# Altium schematic sheet Sensor.SchDoc
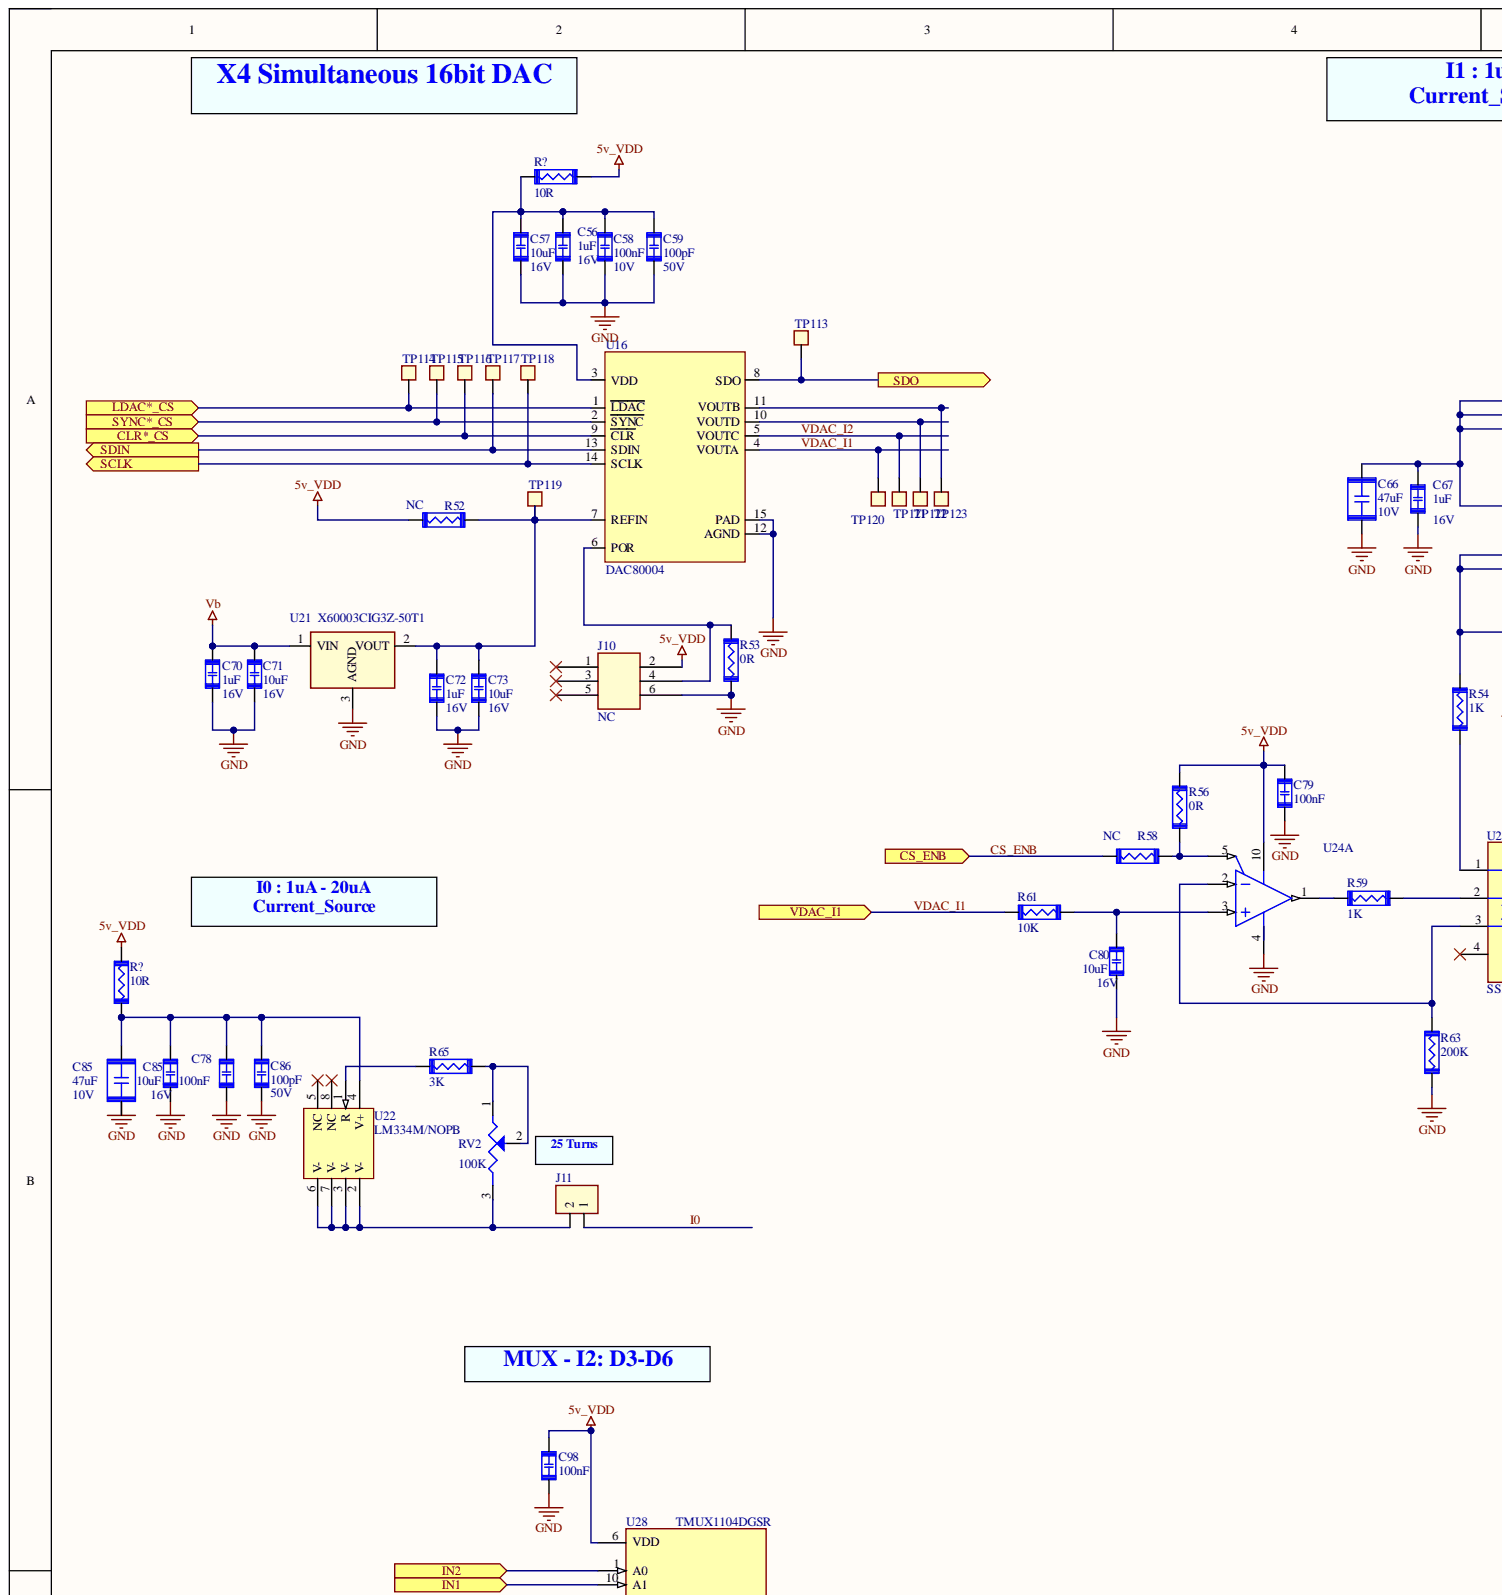
[diagram p0: Sensor.SchDoc, top left]
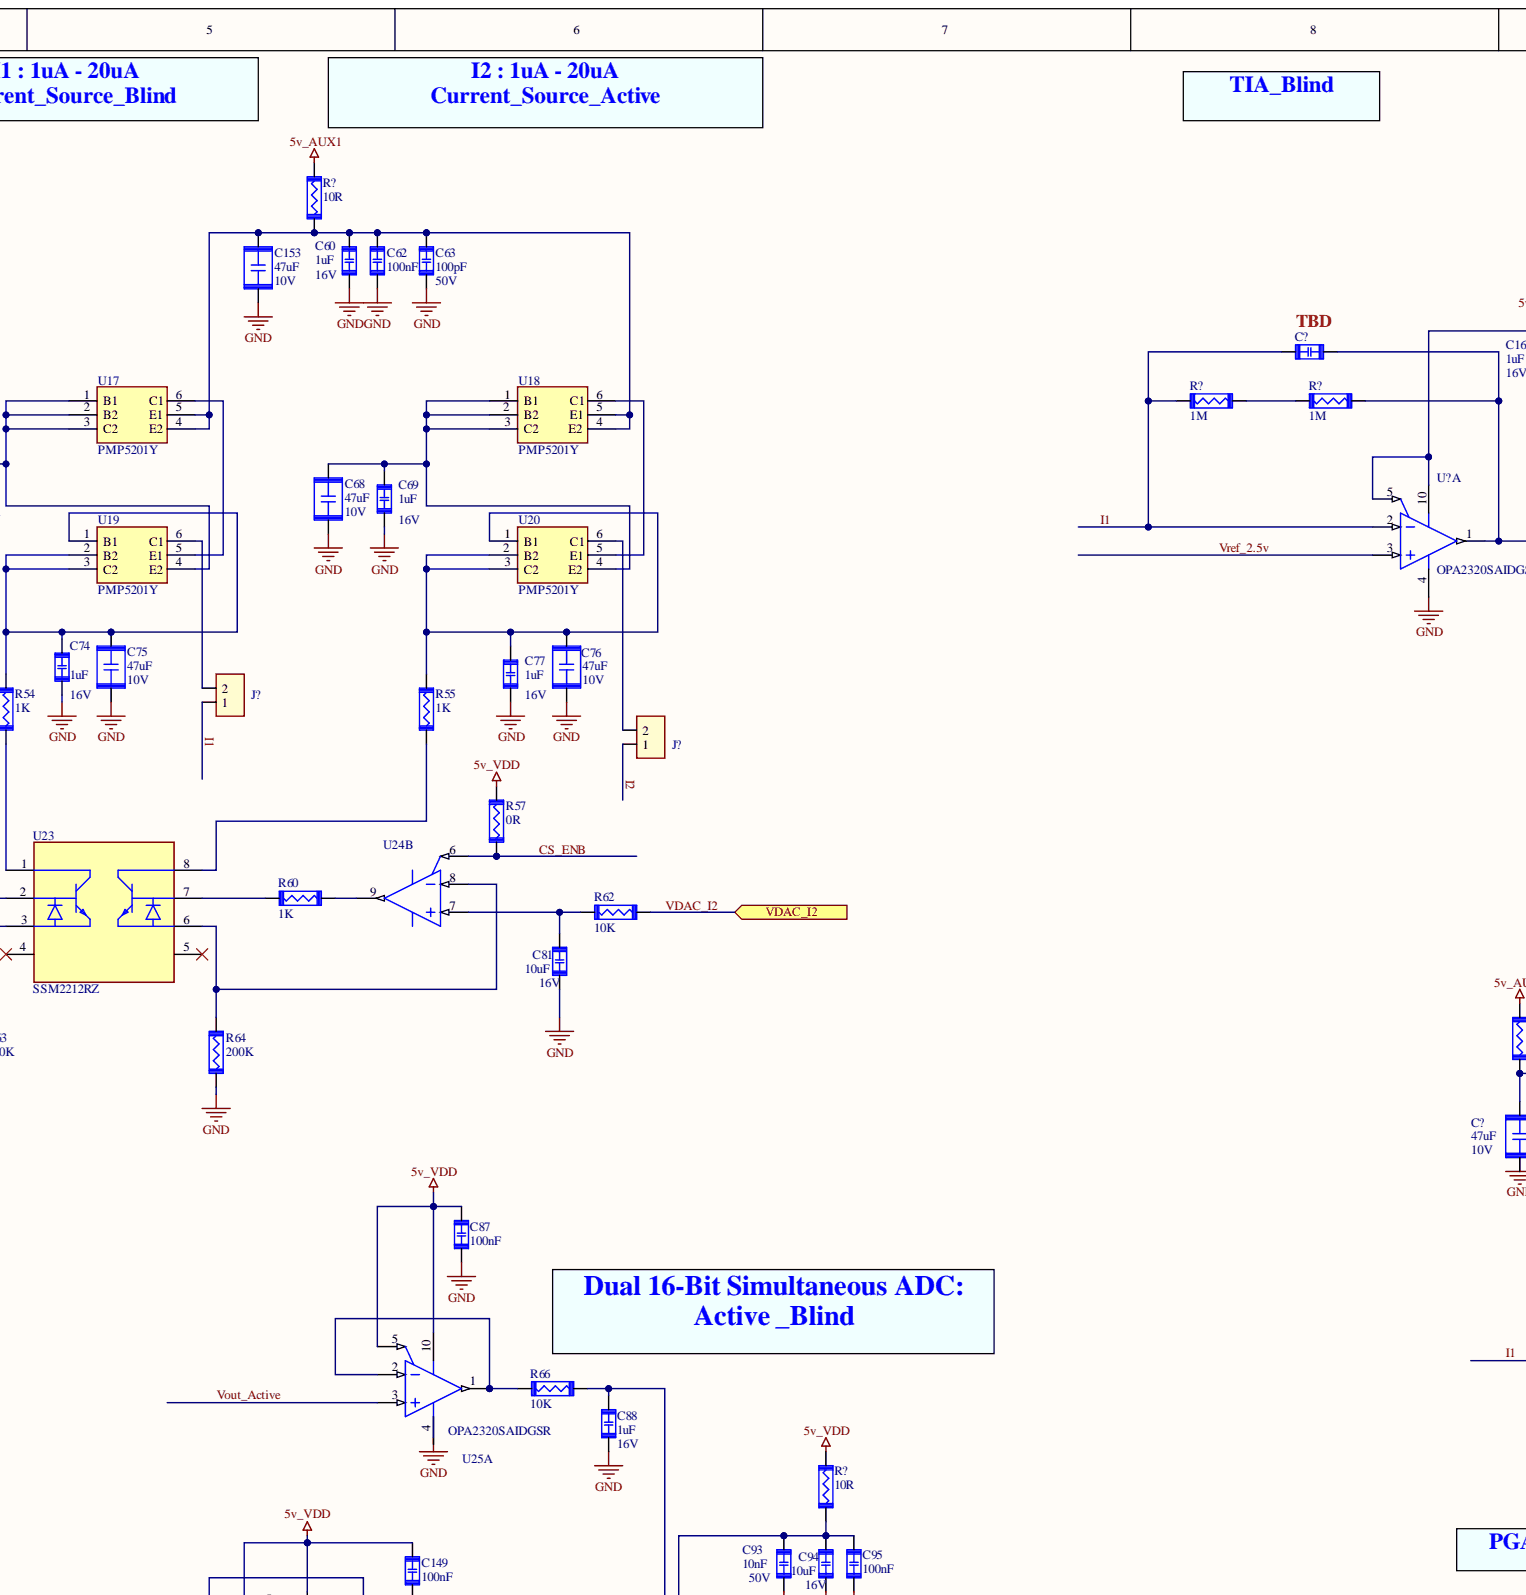
[diagram p1: Sensor.SchDoc, top centre]
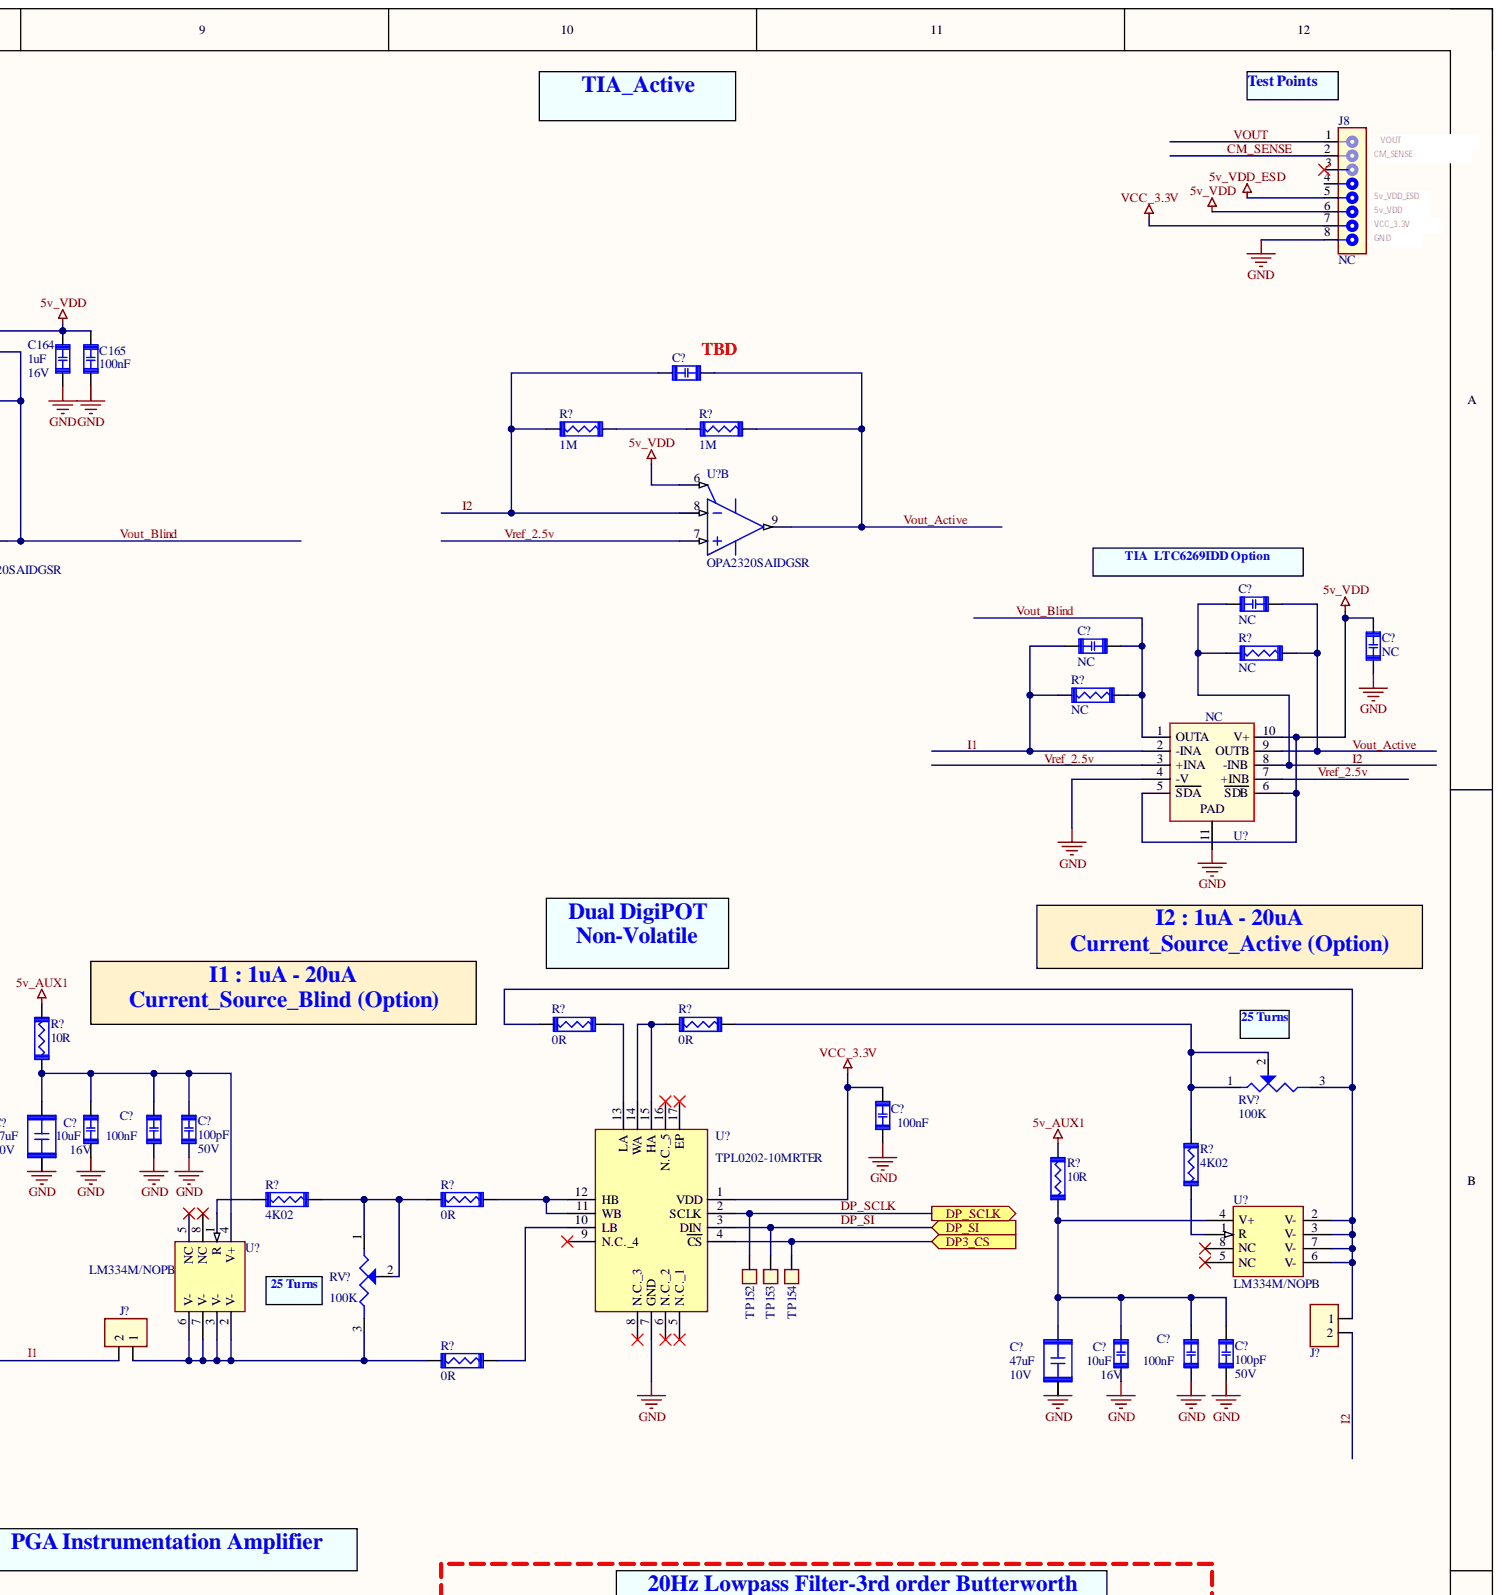
[diagram p2: Sensor.SchDoc, top right]
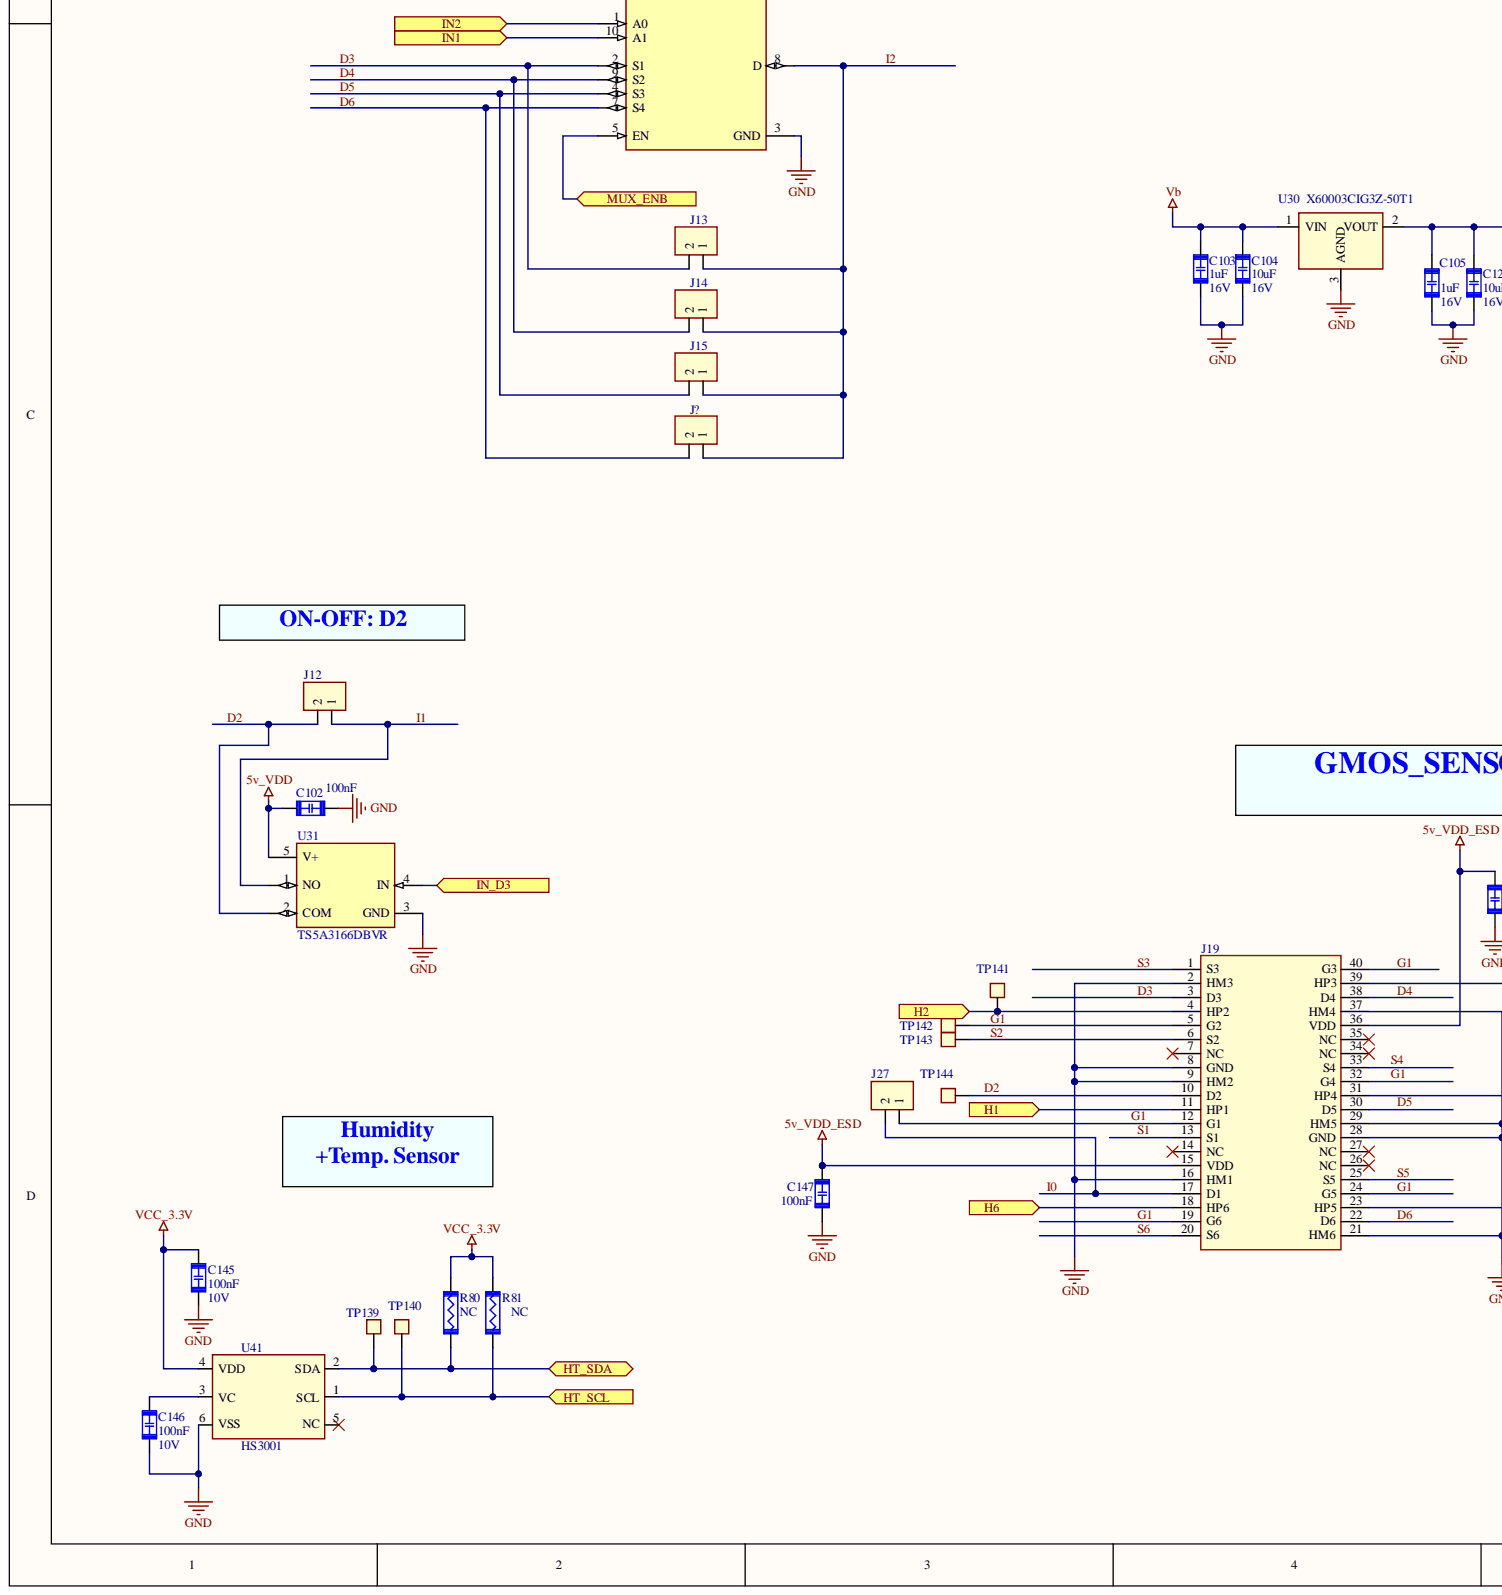
[diagram p3: Sensor.SchDoc, bottom left]
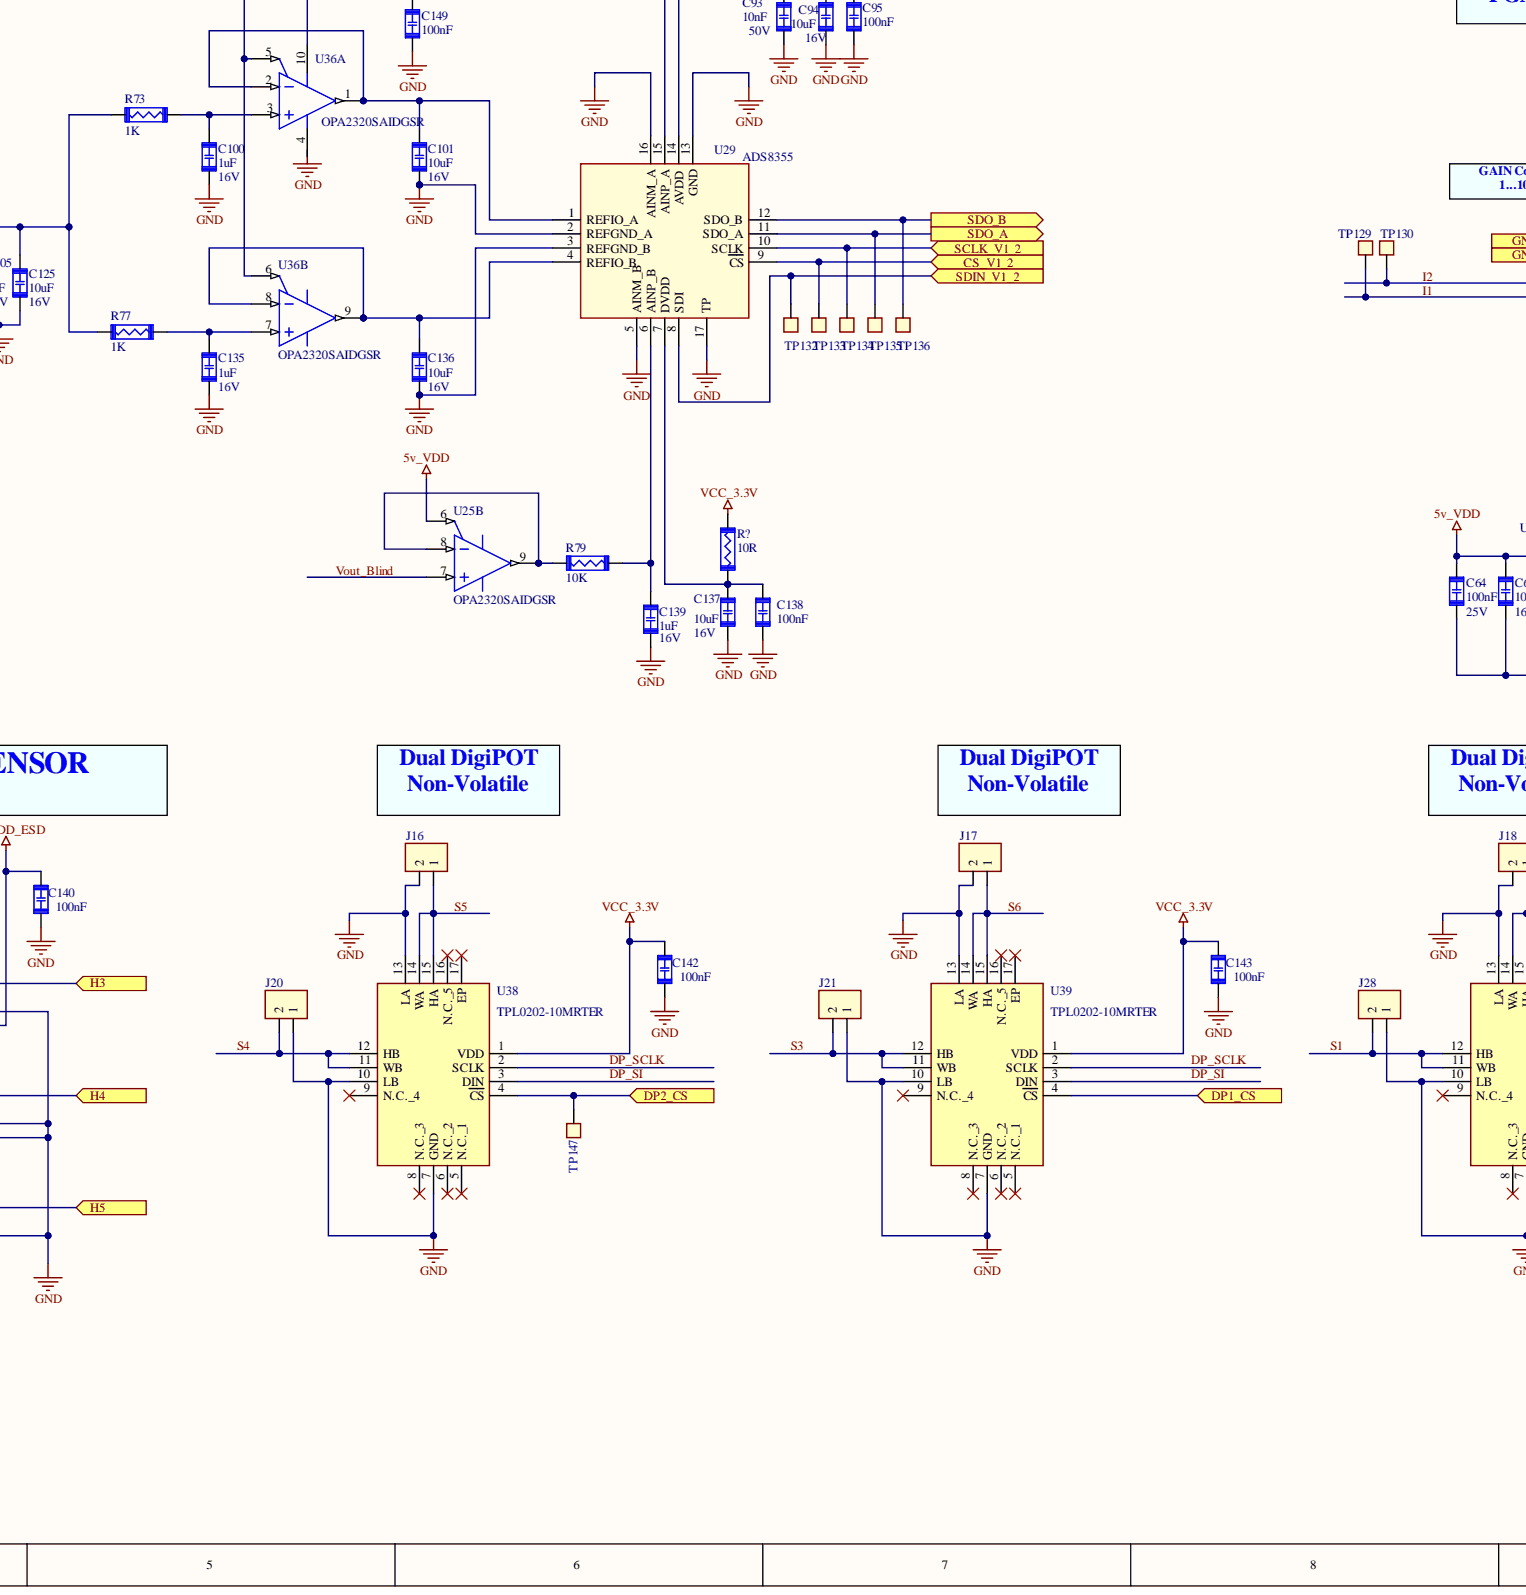
[diagram p4: Sensor.SchDoc, bottom centre]
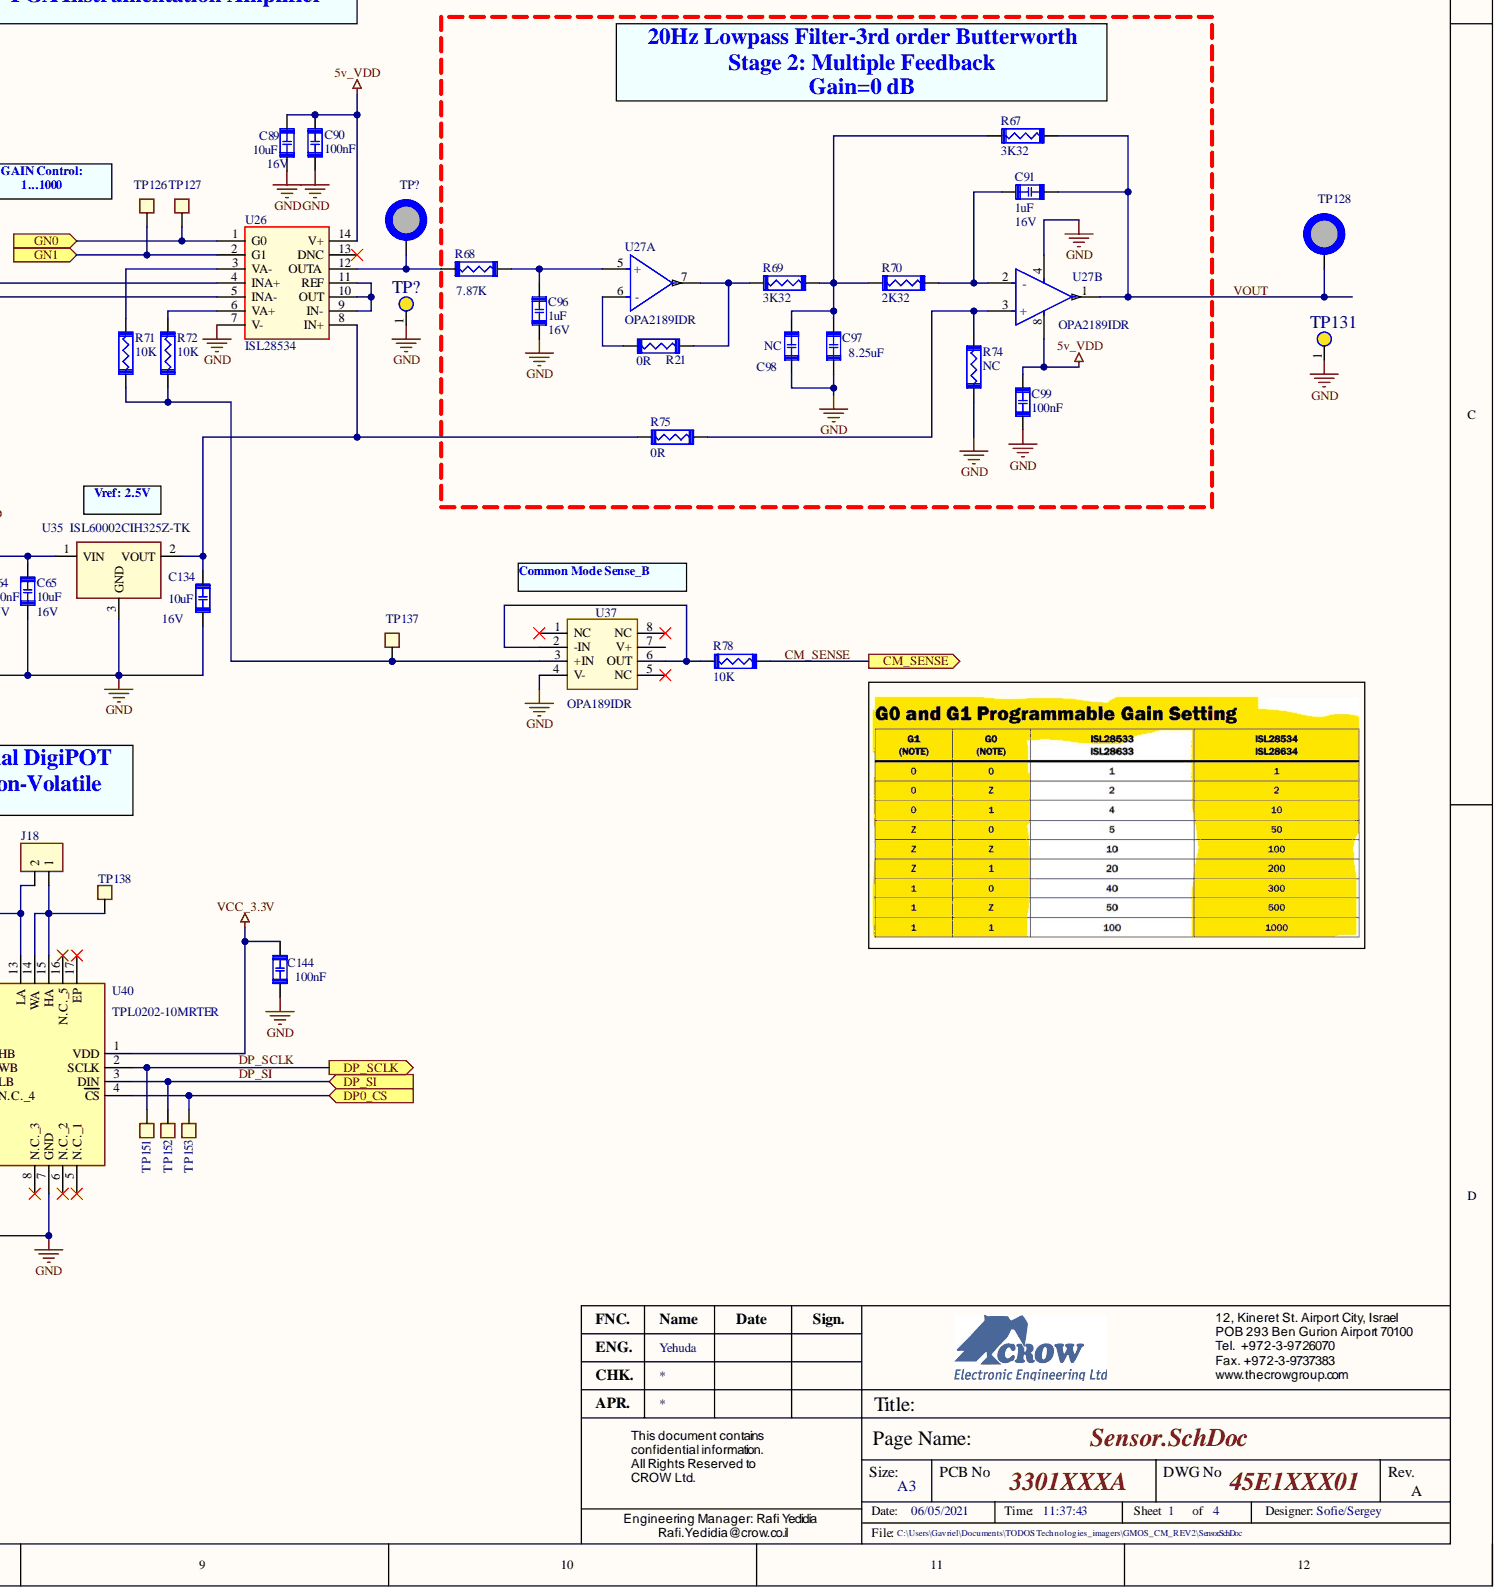
[diagram p5: Sensor.SchDoc, bottom right]

## Parts
C56  1uF  Voltage: 16V  [C0402/C]
C57  10uF  Voltage: 16V  [C0603/C]
C58  100nF  Voltage: 10V  [C0402/C]
C59  100pF  Voltage: 50V  [C0402/C]
C60  1uF  Voltage: 16V  [C0402/C]
C62  100nF  [C0402/C]
C63  100pF  Voltage: 50V  [C0402/C]
C64  100nF  Voltage: 25V  [C0402/C]
C65  10uF  Voltage: 16V  [C0603/C]
C66  47uF  Voltage: 10V  [C1206/C]
C67  1uF  Voltage: 16V  [C0402/C]
C68  47uF  Voltage: 10V  [C1206/C]
C69  1uF  Voltage: 16V  [C0402/C]
C70  1uF  Voltage: 16V  [C0402/C]
C71  10uF  Voltage: 16V  [C0603/C]
C72  1uF  Voltage: 16V  [C0402/C]
C73  10uF  Voltage: 16V  [C0603/C]
C74  1uF  Voltage: 16V  [C0402/C]
C75  47uF  Voltage: 10V  [C1206/C]
C76  47uF  Voltage: 10V  [C1206/C]
C77  1uF  [C0402/C]
C78  100nF  [C0402/C]
C79  100nF  [C0402/C]
C80  10uF  Voltage: 16V  [C0603/C]
C81  10uF  Voltage: 16V  [C0603/C]
C85  47uF  Voltage: 10V  [C1206/C]
C85  10uF  Voltage: 16V  [C0603/C]
C86  100pF  Voltage: 50V  [C0402/C]
C87  100nF  [C0402/C]
C88  1uF  Voltage: 16V  [C0402/C]
C89  10uF  Voltage: 16V  [C0603/C]
C90  100nF  [C0402/C]
C91  1uF  Voltage: 16V  [C0402/C]
C93  10nF  Voltage: 50V  [C0402/C]
C94  10uF  Voltage: 16V  [C0603/C]
C95  100nF  [C0402/C]
C96  1uF  Voltage: 16V  [C0402/C]
C97  8.25uF  [c1206/c]
C98  NC  [c1206/c]
C98  100nF  [C0402/C]
C99  100nF  [C0402/C]
C100  1uF  Voltage: 16V  [C0402/C]
C101  10uF  Voltage: 16V  [C0603/C]
C102  100nF  [C0402/C]
C103  1uF  Voltage: 16V  [C0402/C]
C104  10uF  Voltage: 16V  [C0603/C]
C105  1uF  Voltage: 16V  [C0402/C]
C125  10uF  Voltage: 16V  [C0603/C]
C134  10uF  Voltage: 16V  [C0603/C]
C135  1uF  Voltage: 16V  [C0402/C]
C136  10uF  Voltage: 16V  [C0603/C]
C137  10uF  Voltage: 16V  [C0603/C]
C138  100nF  [C0402/C]
C139  1uF  Voltage: 16V  [C0402/C]
C140  100nF  [C0402/C]
C142  100nF  [C0402/C]
C143  100nF  [C0402/C]
C144  100nF  [C0402/C]
C145  100nF  Voltage: 10V  [C0402/C]
C146  100nF  Voltage: 10V  [C0402/C]
C147  100nF  [C0402/C]
C149  100nF  [C0402/C]
C153  47uF  Voltage: 10V  [C1206/C]
C164  1uF  Voltage: 16V  [C0402/C]
C165  100nF  [C0402/C]
C?  (no value)
C?  NC
C?  NC
C?  (no value)
C?  NC  value 100nF  [C0402/C]
C?  47uF  Voltage: 10V  [C1206/C]
C?  100pF  Voltage: 50V  [C0402/C]
C?  10uF  Voltage: 16V  [C0603/C]
C?  100nF  [C0402/C]
C?  100nF  [C0402/C]
C?  47uF  Voltage: 10V  [C1206/C]
C?  100pF  Voltage: 50V  [C0402/C]
C?  10uF  Voltage: 16V  [C0603/C]
C?  100nF  [C0402/C]
J8  NC  [SIP100_8P_3B_H36.5]
J10  NC  [PH2X3SMT2.54_H2.5]
J11  Header 2p,V.  [SIP100_2/C]  (value not drawn)
J12  Header 2p,V.  [SIP100_2/C]  (value not drawn)
J13  Header 2p,V.  [SIP100_2/C]  (value not drawn)
J14  Header 2p,V.  [SIP100_2/C]  (value not drawn)
J15  Header 2p,V.  [SIP100_2/C]  (value not drawn)
J16  Header 2p,V.  [SIP100_2/C]  (value not drawn)
J17  Header 2p,V.  [SIP100_2/C]  (value not drawn)
J18  Header 2p,V.  [SIP100_2/C]  (value not drawn)
J19  (no value)  [SOCKET40_100]
J20  Header 2p,V.  [SIP100_2/C]  (value not drawn)
J21  Header 2p,V.  [SIP100_2/C]  (value not drawn)
J27  Header 2p,V.  [SIP100_2/C]  (value not drawn)
J28  Header 2p,V.  [SIP100_2/C]  (value not drawn)
J?  Header 2p,V.  [SIP100_2/C]  (value not drawn)
J?  Header 2p,V.  [SIP100_2/C]  (value not drawn)
J?  Header 2p,V.  [SIP100_2/C]  (value not drawn)
J?  Header 2p,V.  [SIP100_2/C]  (value not drawn)
J?  Header 2p,V.  [SIP100_2/C]  (value not drawn)
R21  value 0R  [R0402/C]  (hidden comment: Value)
R52  NC  [R0402/C]
R53  0R  [R0402/C]
R54  1K  [R0402/C]
R55  1K  [R0402/C]
R56  0R  [R0402/C]
R57  0R  [R0402/C]
R58  NC  [R0402/C]
R59  1K  [R0402/C]
R60  1K  [R0402/C]
R61  10K  [R0402/C]
R62  10K  [R0402/C]
R63  200K  [R0402/C]
R64  200K  [R0402/C]
R65  3K  [R0402/C]
R66  10K  [R0402/C]
R67  3K32  [R0603/C]
R68  7.87K
R69  3K32  [R0603/C]
R70  2K32  [R0603/C]
R71  10K  [R0402/C]
R72  10K  [R0402/C]
R73  1K  [R0402/C]
R74  NC  [R0402/C]
R75  0R  [R0402/C]
R77  1K  [R0402/C]
R78  10K  [R0402/C]
R79  10K  [R0402/C]
R80  NC  [R0402/C]
R81  NC  [R0402/C]
R?  1M  [R0402/C]
R?  NC  value 1M  [R0402/C]
R?  NC  value 1M  [R0402/C]
R?  1M  [R0402/C]
R?  1M  [R0402/C]
R?  1M  [R0402/C]
R?  10R  [R0805/C]
R?  0R  [R0402/C]
R?  0R  [R0402/C]
R?  0R  [R0402/C]
R?  0R  [R0402/C]
R?  4K02  [R0402/C]
R?  4K02  [R0402/C]
R?  10R  [R0805/C]
R?  10R  [R0805/C]
R?  10R  [R0805/C]
R?  10R  [R0805/C]
R?  10R  [R0805/C]
R?  10R  [R0805/C]
RV2  100K  [TRIM_PV36W]
RV?  100K  [TRIM_PV36W]
RV?  100K  [TRIM_PV36W]
TP113  TP-1.5MM  [TP-1.5mm]  (value not drawn)  (no BOM)
TP114  TP-1.5MM  [TP-1.5mm]  (value not drawn)  (no BOM)
TP115  TP-1.5MM  [TP-1.5mm]  (value not drawn)  (no BOM)
TP116  TP-1.5MM  [TP-1.5mm]  (value not drawn)  (no BOM)
TP117  TP-1.5MM  [TP-1.5mm]  (value not drawn)  (no BOM)
TP118  TP-1.5MM  [TP-1.5mm]  (value not drawn)  (no BOM)
TP119  =Value  [TP-1.5MM]  (no BOM)
TP120  =Value  [TP-1.5MM]  (no BOM)
TP121  =Value  [TP-1.5MM]  (no BOM)
TP122  =Value  [TP-1.5MM]  (no BOM)
TP123  =Value  [TP-1.5MM]  (no BOM)
TP126  TP-1.5MM  [TP-1.5mm]  (value not drawn)  (no BOM)
TP127  TP-1.5MM  [TP-1.5mm]  (value not drawn)  (no BOM)
TP128  =Value  [TP-1.3mm_TH]  (no BOM)
TP129  TP-1.5MM  [TP-1.5mm]  (value not drawn)  (no BOM)
TP130  TP-1.5MM  [TP-1.5mm]  (value not drawn)  (no BOM)
TP131  =Value  [TP-1.3mm_TH]
TP132  TP-1.5MM  [TP-1.5mm]  (value not drawn)  (no BOM)
TP133  TP-1.5MM  [TP-1.5mm]  (value not drawn)  (no BOM)
TP134  TP-1.5MM  [TP-1.5mm]  (value not drawn)  (no BOM)
TP135  TP-1.5MM  [TP-1.5mm]  (value not drawn)  (no BOM)
TP136  TP-1.5MM  [TP-1.5mm]  (value not drawn)  (no BOM)
TP137  TP-1.5MM  [TP-1.5mm]  (value not drawn)  (no BOM)
TP138  =Value  [TP-1.5MM]  (no BOM)
TP139  =Value  [TP-1.5MM]  (no BOM)
TP140  =Value  [TP-1.5MM]  (no BOM)
TP141  =Value  [TP-1.5MM]  (no BOM)
TP142  =Value  [TP-1.5MM]  (no BOM)
TP143  =Value  [TP-1.5MM]  (no BOM)
TP144  =Value  [TP-1.5MM]  (no BOM)
TP147  =Value  [TP-1.5MM]  (no BOM)
TP151  =Value  [TP-1.5MM]  (no BOM)
TP152  =Value  [TP-1.5MM]  (no BOM)
TP152  =Value  [TP-1.5MM]  (no BOM)
TP153  =Value  [TP-1.5MM]  (no BOM)
TP153  =Value  [TP-1.5MM]  (no BOM)
TP154  =Value  [TP-1.5MM]  (no BOM)
TP?  =Value  [TP-1.3mm_TH]
TP?  =Value  [TP-1.3mm_TH]  (no BOM)
U16  DAC80004  [VSON14_3x4x1_050]
U17  PMP5201Y  [SOT363/C]
U18  PMP5201Y  [SOT363/C]
U19  PMP5201Y  [SOT363/C]
U20  PMP5201Y  [SOT363/C]
U21  X60003CIG3Z-50T1  [SOT23/C]
U22  LM334M/NOPB  [SO_08W/C]
U23  SSM2212RZ  [SO_08W/C]
U24  (no value)  [SOPM_10/C]  (parts A,B)
U25  OPA2320SAIDGSR  [SOPM_10/C]  (parts A,B)
U26  ISL28534  [TSSOP14_4.4X5.0_0.65]
U27  OPA2189IDR  [FP-D0008A-MFG]  (parts A,B)
U28  TMUX1104DGSR  [FP-DGS0010A-MFG]
U29  ADS8355  [WQFN16_3x3x1-050]
U30  X60003CIG3Z-50T1  [SOT23/C]
U31  TS5A3166DBVR  [FP-DBV0005A-MFG]
U35  ISL60002CIH325Z-TK  [SOT23/C]
U36  OPA2320SAIDGSR  [SOPM_10/C]  (parts A,B)
U37  OPA189IDR  [SO_08W/C]
U38  TPL0202-10MRTER  [QFN16_3x3-050]
U39  TPL0202-10MRTER  [QFN16_3x3-050]
U40  TPL0202-10MRTER  [QFN16_3x3-050]
U41  HS3001  [LGA6_3X2.41X0.8]
U?  OPA2320SAIDGSR  [SOPM_10/C]  (parts A,B)
U?  NC  [DFN10_3x3-050]
U?
U?  TPL0202-10MRTER  [QFN16_3x3-050]

## Ports
CLR*_CS, CM_SENSE, CS_ENB, CS_V1_2, DP0_CS, DP1_CS, DP2_CS, DP3_CS, DP_SCLK, DP_SI, GN0, GN1, H1, H2, H3, H4, H5, H6, HT_SCL, HT_SDA, IN1, IN2, IN_D3, LDAC*_CS, MUX_ENB, SCLK, SCLK_V1_2, SDIN, SDIN_V1_2, SDO, SDO_A, SDO_B, SYNC*_CS, VDAC_I1, VDAC_I2

## Nets
5v_AUX1: R?.1 R?.2
5v_VDD: C64.1 C65.1 C79.2 C87.2 C89.1 C90.1 C98.2 C99.1 C102.2 C149.2 C164.1 C165.2 C?.2 J8.6 J10.2 R52.2 R56.1 R57.1 R?.1 R?.2 U24.10 U25.5 U25.6 U25.10 U26.14(V+) U27.8 U28.6(VDD) U31.5(V+) U35.1 U36.5 U36.6 U36.10 U?.5 U?.6 U?.10
5v_VDD_ESD: C140.1 C147.1 J8.5 J19.15(VDD) J19.36(VDD)
GND: C56.2 C57.2 C58.2 C59.2 C60.2 C62.1 C63.2 C64.2 C65.2 C66.2 C67.2 C68.2 C69.2 C70.2 C71.2 C72.2 C73.2 C74.2 C75.2 C76.2 C77.2 C78.2 C79.1 C80.2 C81.2 C85.2 C86.2 C87.1 C88.2 C89.2 C90.2 C93.2 C94.2 C95.1 C96.2 C97.2 C98.1 C98.2 C99.2 C100.2 C101.2 C102.1 C103.2 C104.2 C105.2 C125.2 C134.2 C135.2 C136.2 C137.2 C138.2 C139.2 C140.2 C142.2 C143.2 C144.2 C145.2 C146.2 C147.2 C149.1 C153.2 C164.2 C165.1 C?.1 C?.2 J8.8 J10.6 J16.2 J17.2 J18.2 J19.2(HM3) J19.8(GND) J19.9(HM2) J19.16(HM1) J19.21(HM6) J19.28(GND) J19.29(HM5) J19.37(HM4) J20.1 J21.1 J28.1 R53.2 R63.2 R64.2 R74.1 TP131.1 TP?.1 U16.12(AGND) U16.15(PAD) U21.3 U24.4 U26.7(V-) U27.4 U28.3(GND) U29.2(REFGND_A) U29.3(REFGND_B) U29.5(AINM_B) U29.13 U29.16 U29.17(TP) U30.3 U31.3(GND) U35.3 U37.4(V-) U38.7(GND) U38.10(LB) U38.13(LA) U39.7(GND) U39.10(LB) U39.13(LA) U40.7(GND) U40.10(LB) U40.13(LA) U41.6(VSS) U?.4 U?.7 U?.11
Vb: C70.1 C71.1 C103.1 C104.1 U21.1 U30.1
VCC_3.3V: C142.1 C143.1 C144.1 C145.1 C?.1 J8.7 R80.1 R81.1 R?.1 U38.1(VDD) U39.1(VDD) U40.1(VDD) U41.4(VDD) U?.1
CLR*_CS: TP116.1 U16.9(CLR) ; port CLR*_CS
CM_SENSE: J8.2 R78.2 ; port CM_SENSE
CS_ENB: R57.2 R58.2 U24.6 ; port CS_ENB
CS_V1_2: TP133.1 U29.9(CS) ; port CS_V1_2
D2: J12.2 J19.10(D2) TP144.1 U31.2(COM)
D3: J13.2 J19.3(D3) U28.2(S1)
D4: J14.2 J19.38(D4) U28.9(S2)
D5: J15.2 J19.30(D5) U28.4(S3)
D6: J19.22(D6) J?.2 U28.7(S4)
DP0_CS: TP153.1 U40.4(CS) ; port DP0_CS
DP1_CS: U39.4(CS) ; port DP1_CS
DP2_CS: TP147.1 U38.4(CS) ; port DP2_CS
DP3_CS: TP154.1 U?.4 ; port DP3_CS
DP_SCLK: TP151.1 TP152.1 U38.2(SCLK) U39.2(SCLK) U40.2(SCLK) U?.2 ; port DP_SCLK
DP_SI: TP152.1 TP153.1 U38.3(DIN) U39.3(DIN) U40.3(DIN) U?.3 ; port DP_SI
G1: J19.5(G2) J19.12(G1) J19.19(G6) J19.24(G5) J19.32(G4) J19.40(G3) J27.1 TP142.1
GN0: TP127.1 U26.1(G0) ; port GN0
GN1: TP126.1 U26.2(G1) ; port GN1
H1: J19.11(HP1) ; port H1
H2: J19.4(HP2) TP141.1 ; port H2
H3: J19.39(HP3) ; port H3
H4: J19.31(HP4) ; port H4
H5: J19.23(HP5) ; port H5
H6: J19.18(HP6) ; port H6
HT_SCL: R81.2 TP140.1 U41.1(SCL) ; port HT_SCL
HT_SDA: R80.2 TP139.1 U41.2(SDA) ; port HT_SDA
I0: J11.1 J19.17(D1) J27.2
I1: C?.1 J12.1 J?.1 J?.2 R?.1 TP129.1 U26.5(INA-) U31.1(NO) U?.2
I2: C?.1 J13.1 J14.1 J15.1 J?.1 J?.2 R?.1 TP130.1 U26.4(INA+) U28.8(D) U?.8
IN1: U28.10(A1) ; port IN1
IN2: U28.1(A0) ; port IN2
IN_D3: U31.4(IN) ; port IN_D3
LDAC*_CS: TP114.1 U16.1(LDAC) ; port LDAC*_CS
MUX_ENB: U28.5(EN) ; port MUX_ENB
S1: J19.13(S1) J28.2 U40.11(WB) U40.12(HB)
S2: J19.6(S2) TP143.1
S3: J19.1(S3) J21.2 U39.11(WB) U39.12(HB)
S4: J19.33(S4) J20.2 U38.11(WB) U38.12(HB)
S5: J16.1 J19.25(S5) U38.14 U38.15(HA)
S6: J17.1 J19.20(S6) U39.14 U39.15(HA)
SCLK: TP118.1 U16.14(SCLK) ; port SCLK
SCLK_V1_2: TP134.1 U29.10(SCLK) ; port SCLK_V1_2
SDIN: TP117.1 U16.13(SDIN) ; port SDIN
SDIN_V1_2: TP132.1 U29.8(SDI) ; port SDIN_V1_2
SDO: TP113.1 U16.8(SDO) ; port SDO
SDO_A: TP135.1 U29.11(SDO_A) ; port SDO_A
SDO_B: TP136.1 U29.12(SDO_B) ; port SDO_B
SYNC*_CS: TP115.1 U16.2(SYNC) ; port SYNC*_CS
VDAC_I1: R61.1 TP120.1 U16.4(VOUTA) ; port VDAC_I1
VDAC_I2: R62.2 TP121.1 U16.5(VOUTC) ; port VDAC_I2
VOUT: C91.2 J8.1 R67.2 TP128.1 U27.1
Vout_Active: C?.2 R?.2 U25.3 U?.9
Vout_Blind: C?.2 R?.2 U25.7 U?.1
Vref_2.5v: U?.3 U?.7
NetC56_1: C56.1 C57.1 C58.1 C59.1 R?.1 U16.3(VDD)
NetC60_1: C60.1 C62.2 C63.1 C153.1 R?.2 U17.4(E2) U17.5(E1) U18.4(E2) U18.5(E1)
NetC66_1: C66.1 C67.1 U17.1(B1) U17.2(B2) U17.3(C2) U19.4(E2)
NetC68_1: C68.1 C69.1 U18.1(B1) U18.2(B2) U18.3(C2) U20.4(E2)
NetC72_1: C72.1 C73.1 R52.1 TP119.1 U16.7(REFIN) U21.2
NetC74_1: C74.1 C75.1 R54.1 U19.1(B1) U19.2(B2) U19.3(C2)
NetC76_1: C76.1 C77.1 R55.1 U20.1(B1) U20.2(B2) U20.3(C2)
NetC78_1: C78.1 C85.1 C86.1 R?.1 U22.4
NetC80_1: C80.1 R61.2 U24.3
NetC81_1: C81.1 R62.1 U24.7
NetC88_1: C88.1 R66.2 U29.15
NetC91_1: C91.1 R70.2 U27.2(-)
NetC93_1: C93.1 C94.1 C95.2 R?.2 U29.14(AVDD)
NetC96_1: C96.1 R68.2 U27.5(+)
NetC97_1: C97.1 C98.1 R67.1 R69.2 R70.1
NetC100_1: C100.1 R73.2 U36.3
NetC101_1: C101.1 U29.1(REFIO_A) U36.1 U36.2
NetC105_1: C105.1 C125.1 R73.1 R77.1 U30.2
NetC134_1: C134.1 R75.2 U26.8(IN+) U35.2
NetC135_1: C135.1 R77.2 U36.7
NetC136_1: C136.1 U29.4(REFIO_B) U36.8 U36.9
NetC137_1: C137.1 C138.1 R?.2 U29.7
NetC139_1: C139.1 R79.2 U29.6
NetC146_1: C146.1 U41.3(VC)
NetC?_1: C?.1 R?.1 U?.4
NetC?_2: C?.2
NetJ10_4: J10.4 R53.1 U16.6(POR)
NetJ11_2: J11.2 RV2.3 U22.2 U22.3(V-) U22.6(V-) U22.7(V-)
NetJ18_1: J18.1 TP138.1 U40.14 U40.15(HA)
NetJ?_1: J?.1 R?.1 RV?.3 U?.2 U?.3 U?.6 U?.7
NetJ?_2: J?.2 U19.6(C1) U20.6(C1)
NetR21_1: R21.1 R69.1 U27.7
NetR21_2: R21.2 U27.6(-)
NetR54_2: R54.2 U23.1
NetR55_2: R55.2 U23.8
NetR56_2: R56.2 R58.1 U24.5
NetR59_1: R59.1 U24.1
NetR59_2: R59.2 U23.2
NetR60_1: R60.1 U23.7
NetR60_2: R60.2 U24.9
NetR63_1: R63.1 U23.3 U24.2
NetR64_1: R64.1 U23.6 U24.8
NetR65_1: R65.1 U22.1(R)
NetR65_2: R65.2 RV2.1 RV2.2
NetR66_1: R66.1 U25.1 U25.2
NetR68_1: R68.1 TP?.1 U26.12(OUTA)
NetR71_1: R71.1 U26.3(VA-)
NetR71_2: R71.2 R72.2 TP137.1 U37.3(+IN)
NetR72_1: R72.1 U26.6(VA+)
NetR74_2: R74.2 R75.1 U27.3(+)
NetR78_1: R78.1 U37.2(-IN) U37.6(OUT)
NetR79_1: R79.1 U25.8 U25.9
NetR?_1: R?.1 R?.2 RV?.1 RV?.2 U?.1 U?.14 U?.15
NetR?_2: R?.2 RV?.1 RV?.2 U?.10 U?.11 U?.12(HB) U?.13
NetTP122_1: TP122.1 U16.10(VOUTD)
NetTP123_1: TP123.1 U16.11(VOUTB)
NetU17_6: U17.6(C1) U19.5(E1)
NetU18_6: U18.6(C1) U20.5(E1)
NetU26_9: U26.9(IN-) U26.10(OUT) U26.11(REF)
NetU?_5: U?.5

Not connected: J8 3 4; J10 1 3 5; J19 7(NC) 14(NC) 26(NC) 27(NC) 34(NC) 35(NC); U22 5(NC) 8(NC); U23 4 5; U25 4; U26 13(DNC); U36 4; U37 1(NC) 5(NC) 7(V+) 8(NC); U38 5 6(N.C._2) 8 9(N.C._4) 16(N.C._5) 17; U39 5(N.C._1) 6 8 9(N.C._4) 16 17(EP); U40 5 6 8 9(N.C._4) 16 17; U41 5(NC); U? 16 17
